# Altium schematic sheet DAC7828_main.SchDoc(DAC7828_main)
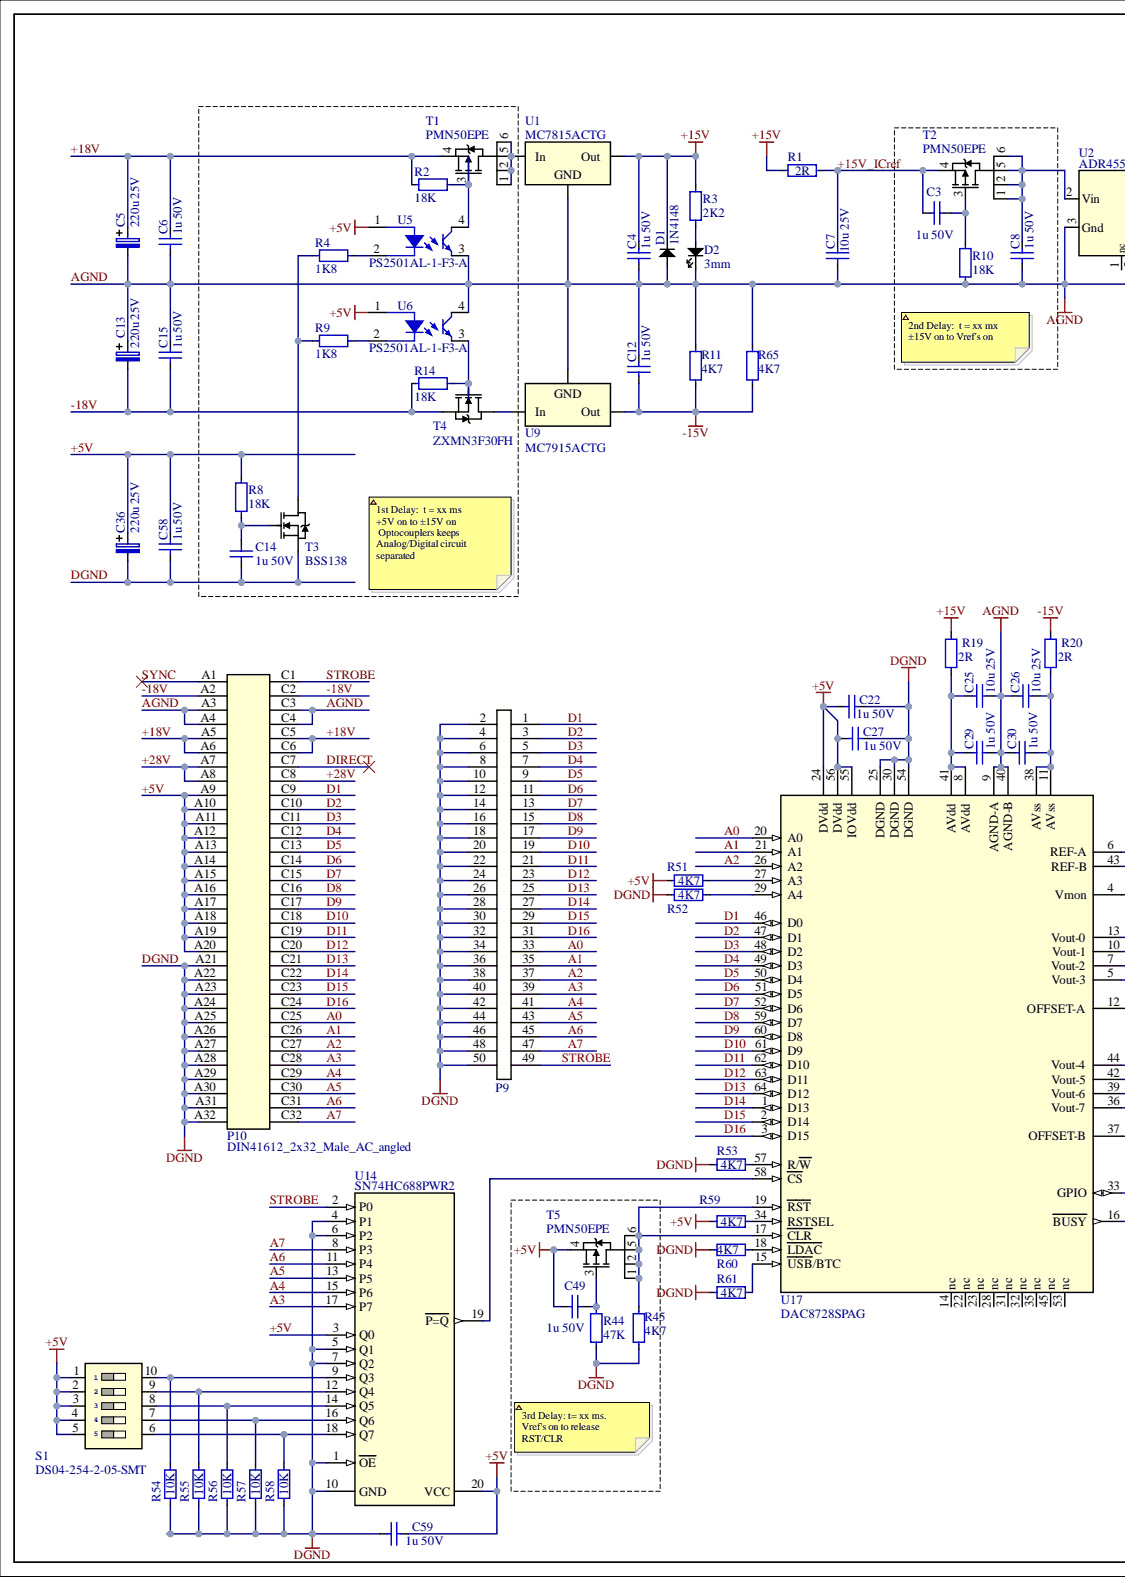
[diagram p0: DAC7828_main.SchDoc, left]
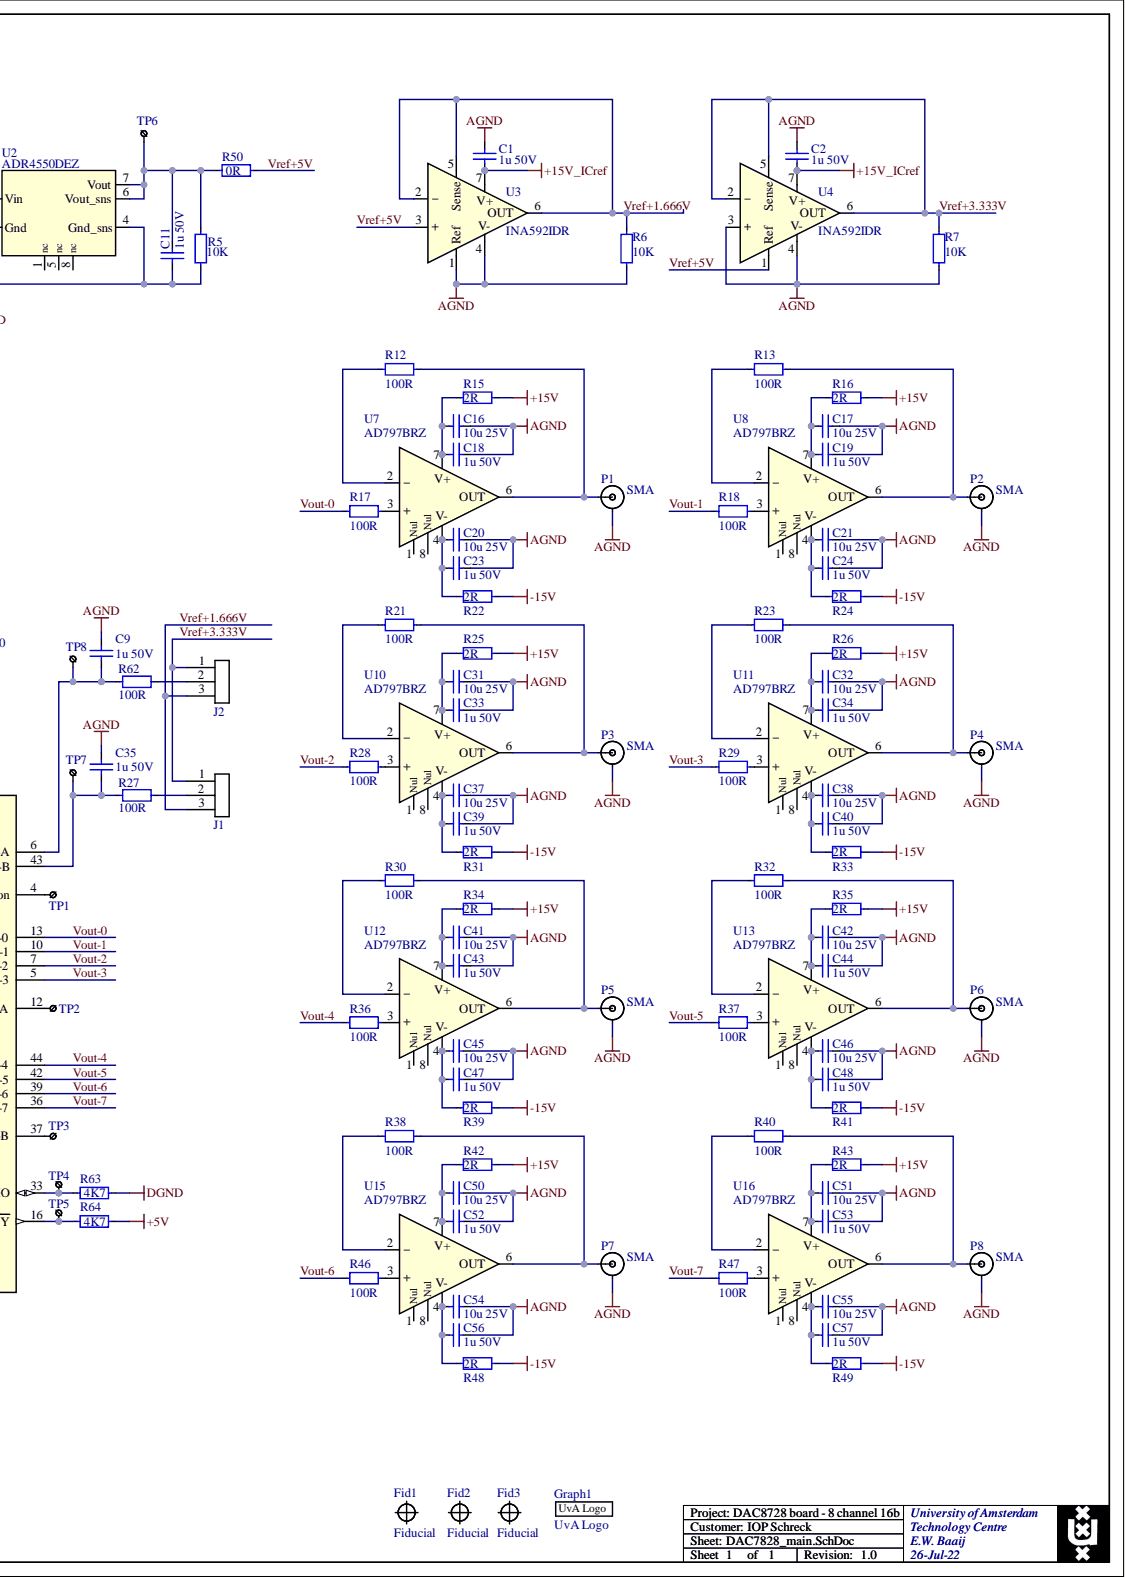
[diagram p1: DAC7828_main.SchDoc, right]

## Parts
C1  1u 50V  Capacitance: 1u  Voltage: 50V  [cap0603MD]
C2  1u 50V  Capacitance: 1u  [cap0603MD]
C3  1u 50V  Capacitance: 1u  Voltage: 50V  [cap0603MD]
C4  1u 50V  Capacitance: 1u  Voltage: 50V  [cap0603MD]
C5  220u 25V  Capacitance: 220u  Voltage: 25V  [capAluSmd_d8H10mm]
C6  1u 50V  Capacitance: 1u  Voltage: 50V  [cap0603MD]
C7  10u 25V  Capacitance: 10u  Voltage: 25V  [cap0805MD]
C8  1u 50V  Capacitance: 1u  Voltage: 50V  [cap0603MD]
C9  1u 50V  Capacitance: 1u  [cap0603MD]
C11  1u 50V  Capacitance: 1u  Voltage: 50V  [cap0603MD]
C12  1u 50V  Capacitance: 1u  Voltage: 50V  [cap0603MD]
C13  220u 25V  Capacitance: 220u  Voltage: 25V  [capAluSmd_d8H10mm]
C14  1u 50V  Capacitance: 1u  Voltage: 50V  [cap0603MD]
C15  1u 50V  Capacitance: 1u  Voltage: 50V  [cap0603MD]
C16  10u 25V  Capacitance: 10u  [cap0805MD]
C17  10u 25V  Capacitance: 10u  [cap0805MD]
C18  1u 50V  Capacitance: 1u  Voltage: 50V  [cap0603MD]
C19  1u 50V  Capacitance: 1u  Voltage: 50V  [cap0603MD]
C20  10u 25V  Capacitance: 10u  [cap0805MD]
C21  10u 25V  Capacitance: 10u  [cap0805MD]
C22  1u 50V  Capacitance: 1u  Voltage: 50V  [cap0603MD]
C23  1u 50V  Capacitance: 1u  Voltage: 50V  [cap0603MD]
C24  1u 50V  Capacitance: 1u  Voltage: 50V  [cap0603MD]
C25  10u 25V  Capacitance: 10u  [cap0805MD]
C26  10u 25V  Capacitance: 10u  [cap0805MD]
C27  1u 50V  Capacitance: 1u  Voltage: 50V  [cap0603MD]
C29  1u 50V  Capacitance: 1u  Voltage: 50V  [cap0603MD]
C30  1u 50V  Capacitance: 1u  Voltage: 50V  [cap0603MD]
C31  10u 25V  Capacitance: 10u  Voltage: 25V  [cap0805MD]
C32  10u 25V  Capacitance: 10u  Voltage: 25V  [cap0805MD]
C33  1u 50V  Capacitance: 1u  Voltage: 50V  [cap0603MD]
C34  1u 50V  Capacitance: 1u  Voltage: 50V  [cap0603MD]
C35  1u 50V  Capacitance: 1u  Voltage: 50V  [cap0603MD]
C36  220u 25V  Capacitance: 220u  Voltage: 25V  [capAluSmd_d8H10mm]
C37  10u 25V  Capacitance: 10u  [cap0805MD]
C38  10u 25V  Capacitance: 10u  [cap0805MD]
C39  1u 50V  Capacitance: 1u  Voltage: 50V  [cap0603MD]
C40  1u 50V  Capacitance: 1u  Voltage: 50V  [cap0603MD]
C41  10u 25V  Capacitance: 10u  [cap0805MD]
C42  10u 25V  Capacitance: 10u  Voltage: 25V  [cap0805MD]
C43  1u 50V  Capacitance: 1u  Voltage: 50V  [cap0603MD]
C44  1u 50V  Capacitance: 1u  Voltage: 50V  [cap0603MD]
C45  10u 25V  Capacitance: 10u  [cap0805MD]
C46  10u 25V  Capacitance: 10u  [cap0805MD]
C47  1u 50V  Capacitance: 1u  Voltage: 50V  [cap0603MD]
C48  1u 50V  Capacitance: 1u  Voltage: 50V  [cap0603MD]
C49  1u 50V  Capacitance: 1u  Voltage: 50V  [cap0603MD]
C50  10u 25V  Capacitance: 10u  Voltage: 25V  [cap0805MD]
C51  10u 25V  Capacitance: 10u  Voltage: 25V  [cap0805MD]
C52  1u 50V  Capacitance: 1u  Voltage: 50V  [cap0603MD]
C53  1u 50V  Capacitance: 1u  Voltage: 50V  [cap0603MD]
C54  10u 25V  Capacitance: 10u  [cap0805MD]
C55  10u 25V  Capacitance: 10u  [cap0805MD]
C56  1u 50V  Capacitance: 1u  Voltage: 50V  [cap0603MD]
C57  1u 50V  Capacitance: 1u  Voltage: 50V  [cap0603MD]
C58  1u 50V  Capacitance: 1u  Voltage: 50V  [cap0603MD]
C59  1u 50V  Capacitance: 1u  Voltage: 50V  [cap0603MD]
D1  1N4148  [SOD2513X100N]
D2  3mm  [LED_3MM_PCB_EDGE_SMD]
Fid1  Fiducial  [fiducial]
Fid2  Fiducial  [fiducial]
Fid3  Fiducial  [fiducial]
Graph1  UvA Logo  Type: Logo  [UvA_Logo]
J1  Header 2.54  [Header254-1x3]  (value not drawn)
J2  Header 2.54  [Header254-1x3]  (value not drawn)
P1  SMA  [619540-1]
P2  SMA  [619540-1]
P3  SMA  [619540-1]
P4  SMA  [619540-1]
P5  SMA  [619540-1]
P6  SMA  [619540-1]
P7  SMA  [619540-1]
P8  SMA  [619540-1]
P9  Header 2.54  [Header254-2x25]  (value not drawn)
P10  DIN41612_2x32_Male_AC_angled  [DIN41612_2x32_Male_AC_angled]
R1  2R  [res0603MD]
R2  18K  [res0603MD]
R3  2K2  [res0603MD]
R4  1K8  [res0603MD]
R5  10K  [res0603MD]
R6  10K  [res0603MD]
R7  10K  [res0603MD]
R8  18K  [res0603MD]
R9  1K8  [res0603MD]
R10  18K  [res0603MD]
R11  4K7  [res0603MD]
R12  100R  [res0603MD]
R13  100R  [res0603MD]
R14  18K  [res0603MD]
R15  2R  [res0603MD]
R16  2R  [res0603MD]
R17  100R  [res0603MD]
R18  100R  [res0603MD]
R19  2R  [res0603MD]
R20  2R  [res0603MD]
R21  100R  [res0603MD]
R22  2R  [res0603MD]
R23  100R  [res0603MD]
R24  2R  [res0603MD]
R25  2R  [res0603MD]
R26  2R  [res0603MD]
R27  100R  [res0603MD]
R28  100R  [res0603MD]
R29  100R  [res0603MD]
R30  100R  [res0603MD]
R31  2R  [res0603MD]
R32  100R  [res0603MD]
R33  2R  [res0603MD]
R34  2R  [res0603MD]
R35  2R  [res0603MD]
R36  100R  [res0603MD]
R37  100R  [res0603MD]
R38  100R  [res0603MD]
R39  2R  [res0603MD]
R40  100R  [res0603MD]
R41  2R  [res0603MD]
R42  2R  [res0603MD]
R43  2R  [res0603MD]
R44  47K  [res0603MD]
R45  4K7  [res0603MD]
R46  100R  [res0603MD]
R47  100R  [res0603MD]
R48  2R  [res0603MD]
R49  2R  [res0603MD]
R50  0R  [res0603MD]
R51  4K7  [res0603MD]
R52  4K7  [res0603MD]
R53  4K7  [res0603MD]
R54  10K  [res0603HD]
R55  10K  [res0603HD]
R56  10K  [res0603HD]
R57  10K  [res0603HD]
R58  10K  [res0603HD]
R59  4K7  [res0603MD]
R60  4K7  [res0603MD]
R61  4K7  [res0603MD]
R62  100R  [res0603MD]
R63  4K7  [res0603MD]
R64  4K7  [res0603MD]
R65  4K7  [res0603MD]
S1  DS04-254-2-05-SMT  [DS04-254-2-05-SMT]
T1  PMN50EPE  [TSOP95P280X110-6N]
T2  PMN50EPE  [TSOP95P280X110-6N]
T3  BSS138  [SOT95P230X110-3N]
T4  ZXMN3F30FH  [SOT95P230X110-3N]
T5  PMN50EPE  [TSOP95P280X110-6N]
TP1  Testpoint  [Testpoint_1mm]  (value not drawn)  (no BOM)
TP2  Testpoint  [Testpoint_1mm]  (value not drawn)  (no BOM)
TP3  Testpoint  [Testpoint_1mm]  (value not drawn)  (no BOM)
TP4  Testpoint  [Testpoint_1mm]  (value not drawn)  (no BOM)
TP5  Testpoint  [Testpoint_1mm]  (value not drawn)  (no BOM)
TP6  Testpoint  [Testpoint_1mm]  (value not drawn)  (no BOM)
TP7  Testpoint  [Testpoint_1mm]  (value not drawn)  (no BOM)
TP8  Testpoint  [Testpoint_1mm]  (value not drawn)  (no BOM)
U1  MC7815ACTG  [TO220-3_FLAT]
U2  ADR4550DEZ  [LCCS127P503X450X221-8M]
U3  INA592IDR  [SOIC127P600X175-8N]
U4  INA592IDR  [SOIC127P600X175-8N]
U5  PS2501AL-1-F3-A  [SOP254P960X380-4N]
U6  PS2501AL-1-F3-A  [SOP254P960X380-4N]
U7  AD797BRZ  [SOIC127P600X175-8N]
U8  AD797BRZ  [SOIC127P600X175-8N]
U9  MC7915ACTG  [TO220-3_FLAT]
U10  AD797BRZ  [SOIC127P600X175-8N]
U11  AD797BRZ  [SOIC127P600X175-8N]
U12  AD797BRZ  [SOIC127P600X175-8N]
U13  AD797BRZ  [SOIC127P600X175-8N]
U14  SN74HC688PWR2  [SOIC127P1030X265-20N]
U15  AD797BRZ  [SOIC127P600X175-8N]
U16  AD797BRZ  [SOIC127P600X175-8N]
U17  DAC8728SPAG  [TSQFP50P1200X1200X105-64N]

## Nets
+15V: C4.1 D1.1 R1.2 R3.2 R15.1 R16.1 R19.2 R25.1 R26.1 R34.1 R35.1 R42.1 R43.1 U1.3
-15V: C12.2 R11.1 R20.2 R22.1 R24.1 R31.1 R33.1 R39.1 R41.1 R48.1 R49.1 R65.1 U9.3
+5V: C22.1 C27.1 C36.P C49.1 C58.1 C59.2 P10.A9 P10.A10 P10.A11 P10.A12 P10.A13 P10.A14 P10.A15 P10.A16 P10.A17 P10.A18 P10.A19 P10.A20 R8.2 R51.1 R59.1 R64.2 S1.1 S1.2 S1.3 S1.4 S1.5 T5.4 U5.1 U6.1 U14.3(Q0) U14.20(VCC) U17.24(DVdd) U17.55(IOVdd) U17.56(DVdd)
+15V_ICref: C1.1 C2.1 C3.1 C7.2 R1.1 T2.4 U3.7(V+) U4.7(V+)
+18V: C5.P C6.1 P10.A5 P10.A6 P10.C5 P10.C6 R2.1 T1.4
+28V: P10.A7 P10.A8 P10.C8
-18V: C13.N C15.2 P10.A2 P10.C2 R14.1 T4.2
A0: P9.33 P10.C25 U17.20(A0)
A1: P9.35 P10.C26 U17.21(A1)
A2: P9.37 P10.C27 U17.26(A2)
A3: P9.39 P10.C28 U14.17(P7)
A4: P9.41 P10.C29 U14.15(P6)
A5: P9.43 P10.C30 U14.13(P5)
A6: P9.45 P10.C31 U14.11(P4)
A7: P9.47 P10.C32 U14.8(P3)
AGND: C1.2 C2.2 C4.2 C5.N C6.2 C7.1 C8.2 C9.2 C11.2 C12.1 C13.P C15.1 C16.2 C17.2 C18.2 C19.2 C20.2 C21.2 C23.2 C24.2 C25.2 C26.1 C29.1 C30.2 C31.2 C32.2 C33.2 C34.2 C35.2 C37.2 C38.2 C39.2 C40.2 C41.2 C42.2 C43.2 C44.2 C45.2 C46.2 C47.2 C48.2 C50.2 C51.2 C52.2 C53.2 C54.2 C55.2 C56.2 C57.2 D1.2 D2.1 P1.2 P2.2 P3.2 P4.2 P5.2 P6.2 P7.2 P8.2 P10.A3 P10.A4 P10.C3 P10.C4 R5.1 R6.1 R7.1 R10.1 R11.2 R65.2 U1.2 U2.3(Gnd) U2.4(Gnd_sns) U3.1(Ref) U3.4(V-) U4.3(+) U4.4(V-) U5.3 U6.4 U9.1 U17.9(AGND-A) U17.40(AGND-B)
D1: P9.1 P10.C9 U17.46(D0)
D2: P9.3 P10.C10 U17.47(D1)
D3: P9.5 P10.C11 U17.48(D2)
D4: P9.7 P10.C12 U17.49(D3)
D5: P9.9 P10.C13 U17.50(D4)
D6: P9.11 P10.C14 U17.51(D5)
D7: P9.13 P10.C15 U17.52(D6)
D8: P9.15 P10.C16 U17.59(D7)
D9: P9.17 P10.C17 U17.60(D8)
D10: P9.19 P10.C18 U17.61(D9)
D11: P9.21 P10.C19 U17.62(D10)
D12: P9.23 P10.C20 U17.63(D11)
D13: P9.25 P10.C21 U17.64(D12)
D14: P9.27 P10.C22 U17.1(D13)
D15: P9.29 P10.C23 U17.2(D14)
D16: P9.31 P10.C24 U17.3(D15)
DGND: C14.2 C22.2 C27.2 C36.N C58.2 C59.1 P9.2 P9.4 P9.6 P9.8 P9.10 P9.12 P9.14 P9.16 P9.18 P9.20 P9.22 P9.24 P9.26 P9.28 P9.30 P9.32 P9.34 P9.36 P9.38 P9.40 P9.42 P9.44 P9.46 P9.48 P9.50 P10.A21 P10.A22 P10.A23 P10.A24 P10.A25 P10.A26 P10.A27 P10.A28 P10.A29 P10.A30 P10.A31 P10.A32 R44.1 R45.1 R52.1 R53.1 R54.1 R55.1 R56.1 R57.1 R58.1 R60.1 R61.1 R63.2 T3.2 U14.1(OE) U14.4(P1) U14.5(Q1) U14.6(P2) U14.7(Q2) U14.10(GND) U17.25(DGND) U17.30(DGND) U17.54(DGND)
DIRECT: P10.C7
STROBE: P9.49 P10.C1 U14.2(P0)
SYNC: P10.A1
Vout-0: R17.1 U17.13(Vout-0)
Vout-1: R18.1 U17.10(Vout-1)
Vout-2: R28.1 U17.7(Vout-2)
Vout-3: R29.1 U17.5(Vout-3)
Vout-4: R36.1 U17.44(Vout-4)
Vout-5: R37.1 U17.42(Vout-5)
Vout-6: R46.1 U17.39(Vout-6)
Vout-7: R47.1 U17.36(Vout-7)
Vref+1.666V: J1.3 J2.3 R6.2 U3.2(-) U3.5(Sense) U3.6(OUT)
Vref+3.333V: J1.1 J2.1 R7.2 U4.2(-) U4.5(Sense) U4.6(OUT)
Vref+5V: R50.2 U3.3(+) U4.1(Ref)
NetC3_2: C3.2 R10.2 T2.3
NetC8_1: C8.1 T2.1 T2.2 T2.5 T2.6 U2.2(Vin)
NetC9_1: C9.1 R62.1 TP8.1 U17.6(REF-A)
NetC11_1: C11.1 R5.2 R50.1 TP6.1 U2.6(Vout_sns) U2.7(Vout)
NetC14_1: C14.1 R8.1 T3.1
NetC16_1: C16.1 C18.1 R15.2 U7.7(V+)
NetC17_1: C17.1 C19.1 R16.2 U8.7(V+)
NetC20_1: C20.1 C23.1 R22.2 U7.4(V-)
NetC21_1: C21.1 C24.1 R24.2 U8.4(V-)
NetC25_1: C25.1 C29.2 R19.1 U17.8(AVdd) U17.41(AVdd)
NetC26_2: C26.2 C30.1 R20.1 U17.11(AVss) U17.38(AVss)
NetC31_1: C31.1 C33.1 R25.2 U10.7(V+)
NetC32_1: C32.1 C34.1 R26.2 U11.7(V+)
NetC35_1: C35.1 R27.1 TP7.1 U17.43(REF-B)
NetC37_1: C37.1 C39.1 R31.2 U10.4(V-)
NetC38_1: C38.1 C40.1 R33.2 U11.4(V-)
NetC41_1: C41.1 C43.1 R34.2 U12.7(V+)
NetC42_1: C42.1 C44.1 R35.2 U13.7(V+)
NetC45_1: C45.1 C47.1 R39.2 U12.4(V-)
NetC46_1: C46.1 C48.1 R41.2 U13.4(V-)
NetC49_2: C49.2 R44.2 T5.3
NetC50_1: C50.1 C52.1 R42.2 U15.7(V+)
NetC51_1: C51.1 C53.1 R43.2 U16.7(V+)
NetC54_1: C54.1 C56.1 R48.2 U15.4(V-)
NetC55_1: C55.1 C57.1 R49.2 U16.4(V-)
NetD2_2: D2.2 R3.1
NetJ1_2: J1.2 R27.2
NetJ2_2: J2.2 R62.2
NetP1_1: P1.1 R12.2 U7.6(OUT)
NetP2_1: P2.1 R13.2 U8.6(OUT)
NetP3_1: P3.1 R21.2 U10.6(OUT)
NetP4_1: P4.1 R23.2 U11.6(OUT)
NetP5_1: P5.1 R30.2 U12.6(OUT)
NetP6_1: P6.1 R32.2 U13.6(OUT)
NetP7_1: P7.1 R38.2 U15.6(OUT)
NetP8_1: P8.1 R40.2 U16.6(OUT)
NetR2_2: R2.2 T1.3 U5.4
NetR4_1: R4.1 R9.1 T3.3
NetR4_2: R4.2 U5.2
NetR9_2: R9.2 U6.2
NetR12_1: R12.1 U7.2(-)
NetR13_1: R13.1 U8.2(-)
NetR14_2: R14.2 T4.1 U6.3
NetR17_2: R17.2 U7.3(+)
NetR18_2: R18.2 U8.3(+)
NetR21_1: R21.1 U10.2(-)
NetR23_1: R23.1 U11.2(-)
NetR28_2: R28.2 U10.3(+)
NetR29_2: R29.2 U11.3(+)
NetR30_1: R30.1 U12.2(-)
NetR32_1: R32.1 U13.2(-)
NetR36_2: R36.2 U12.3(+)
NetR37_2: R37.2 U13.3(+)
NetR38_1: R38.1 U15.2(-)
NetR40_1: R40.1 U16.2(-)
NetR45_2: R45.2 T5.1 T5.2 T5.5 T5.6 U17.17(CLR) U17.19(RST)
NetR46_2: R46.2 U15.3(+)
NetR47_2: R47.2 U16.3(+)
NetR51_2: R51.2 U17.27(A3)
NetR52_2: R52.2 U17.29(A4)
NetR53_2: R53.2 U17.57(R/W)
NetR54_2: R54.2 S1.10 U14.9(Q3)
NetR55_2: R55.2 S1.9 U14.12(Q4)
NetR56_2: R56.2 S1.8 U14.14(Q5)
NetR57_2: R57.2 S1.7 U14.16(Q6)
NetR58_2: R58.2 S1.6 U14.18(Q7)
NetR59_2: R59.2 U17.34(RSTSEL)
NetR60_2: R60.2 U17.18(LDAC)
NetR61_2: R61.2 U17.15(USB/BTC)
NetR63_1: R63.1 TP4.1 U17.33(GPIO)
NetR64_1: R64.1 TP5.1 U17.16(BUSY)
NetT1_1: T1.1 T1.2 T1.5 T1.6 U1.1
NetT4_3: T4.3 U9.2
NetTP1_1: TP1.1 U17.4(Vmon)
NetTP2_1: TP2.1 U17.12(OFFSET-A)
NetTP3_1: TP3.1 U17.37(OFFSET-B)
NetU14_19: U14.19(P=Q) U17.58(CS)

Not connected: U2 1(nc) 5(nc) 8(nc); U7 1(Nul) 8(Nul); U8 1(Nul) 8(Nul); U10 1(Nul) 8(Nul); U11 1(Nul) 8(Nul); U12 1(Nul) 8(Nul); U13 1(Nul) 8(Nul); U15 1(Nul) 8(Nul); U16 1(Nul) 8(Nul); U17 14(nc) 22(nc) 23(nc) 28(nc) 31(nc) 32(nc) 35(nc) 45(nc) 53(nc)

## Library data of the parts
C1-C4 C6 C8 C9 C11 C12 C14 C15 C18 C19 C22-C24 C27 C29 C30 C33-C35 C39 C40 C43 C44 C47-C49 C52 C53 C56-C59 = Cap0603MD_1u_X5R_50V_10%: Database Table Name: capacitor_generic; id: 123; Precision: 10%; Type: X5R; Component Kind: Standard; CreationDate: 23-Mar-20; Library Name: TC_Parts.DbLib; MyComment: 1u 50V; Partname: Cap0603MD_1u_X5R_50V_10%; Supplier 1: Farnell; Supplier Part Number 1: 3013383; Author: Twe; Checked by: Unchecked
  C2 C9: Voltage: 50V
  C18 C23: ClassName: OutBufClass0
  C19 C24: ClassName: OutBufClass1
C5 C13 C36 = EDK227M025A9MAA: Cap Alu SMD d8h11, ±20%; Manufacturer: Kemet; Database Table Name: capacitor_named; id: 37; Component Kind: Standard; CreationDate: 06-Jul-22 14:38:19; Library Name: TC_Parts.DbLib; ManufacturerCode: EDK227M025A9MAA; MyComment: 220u 25V; Partname: EDK227M025A9MAA; Precision: 20%; Supplier 1: Digi-Key; Supplier Part Number 1: 399-18129-1-ND; Author: EB; Checked by: Unchecked
C7 C16 C17 C20 C21 C25 C26 C31 C32 C37 C38 C41 C42 C45 C46 C50 C51 C54 C55 = Cap0805MD_10u_X5R_25V_10%: Database Table Name: capacitor_generic; id: 146; Precision: 10%; Type: 5XR; Component Kind: Standard; CreationDate: 31-Mar-16 14:12:18; Library Name: TC_Parts.DbLib; MyComment: 10u 25V; Partname: Cap0805MD_10u_X5R_25V_10%; Supplier 1: Farnell; Supplier Part Number 1: 1735530; Author: EB; Checked by: Unchecked
  C16 C20: ClassName: OutBufClass0; Voltage: 25V
  C17 C21: ClassName: OutBufClass1; Voltage: 25V
  C25 C26 C37 C38 C41 C45 C46 C54 C55: Voltage: 25V
D1 = 1N4148WS: Small Signal Diode, Single, 100 V, 150 mA, 1 V, 4 ns, 350 mA; Manufacturer: Multicomp; id: 15; Type: General Purpose; Component Kind: Standard; CreationDate: 26-Aug-16 13:43:40; Database Table Name: diode; Library Name: TC_Parts.DbLib; ManufacturerCode: 1N4148WS; MyComment: 1N4148; Partname: 1N4148WS; Supplier 1: Farnell; Supplier Part Number 1: 1466524; Author: Twe; Checked by: PCB
D2 = LED_3mm_PcbEdgeSmd: Generic 3mm LED Footprint PCB Edge SMD Pads; Database Table Name: diode; id: 40; Component Kind: Standard; CreationDate: 26-May-16 08:35:38; Library Name: TC_Parts.DbLib; MyComment: 3mm; Partname: LED_3mm_PcbEdgeSmd; Supplier 1: Farnell; Type: LED; Author: EB; Checked by: Unchecked
Fid1-Fid3 = Fiducial: Database Table Name: miscellaneous; id: 1; Component Kind: Standard; CreationDate: 31-Mar-16 09:08:33; Description: Fiducial; Library Name: TC_Parts.DbLib; MyComment: Fiducial; Partname: Fiducial; Supplier 1: Farnell; Type: Fiducial; Author: Twe; Checked by: PCB
Graph1 = UvA_Logo: University of Amsterdam Logo; Database Table Name: miscellaneous; id: 4; Component Kind: Standard; CreationDate: 31-Mar-16 13:43:00; Library Name: TC_Parts.DbLib; MyComment: UvA Logo; Partname: UvA_Logo; Supplier 1: Farnell; Author: Twe; Checked by: PCB
J1 J2 = Header254-1x3: Header 2.54p 1x3; Database Table Name: connector; id: 33; Component Kind: Standard; CreationDate: 11-Apr-16 15:00:36; Library Name: TC_Parts.DbLib; MyComment: Header 2.54; Partname: Header254-1x3; Supplier 1: Farnell; Supplier Part Number 1: 1022248; Type: Header; Author: Twe; Checked by: PCB
P1-P8 = 619540-1: SMA right angle through hole, 19mm, 50 Ohm; Manufacturer: TE connectivity; Database Table Name: connector; id: 145; Component Kind: Standard; CreationDate: 31-Mar-16 12:11:50; Library Name: TC_Parts.DbLib; ManufacturerCode: 619540-1; MyComment: SMA; Partname: 619540-1; Supplier 1: Farnell; Supplier Part Number 1: 3133848; Type: SMA; Author: EB; Checked by: Unchecked
P9 = Header254-2x25: Header 2.54p 2x25; Manufacturer: Multicomp; ManufacturerCode: 2213S-50G; Database Table Name: connector; id: 146; Component Kind: Standard; CreationDate: 18-Jan-17 13:47:01; Library Name: TC_Parts.DbLib; MyComment: Header 2.54; Partname: Header254-2x25; Supplier 1: Farnell; Supplier Part Number 1: 2847228; Type: Header; Author: EB; Checked by: Unchecked
P10 = DIN41612: Manufacturer: Hartman; Database Table Name: connector; id: 144; Component Kind: Standard; CreationDate: 28-Jun-22 09:22:47; Description: DIN41612_2x32_Male_AC_angled; Library Name: TC_Parts.DbLib; MyComment: DIN41612_2x32_Male_AC_angled; Partname: DIN41612; Supplier 1: Farnell; Type: Connector; Author: EB; Checked by: Unchecked
R1 R15 R16 R19 R20 R22 R24-R26 R31 R33-R35 R39 R41-R43 R48 R49 = Res0603MD_2R_1%: Database Table Name: resistor_generic; id: 536; Precision: 1%; Component Kind: Standard; CreationDate: 30-Mar-16 10:33:13; Library Name: TC_Parts.DbLib; MyComment: 2R; Partname: Res0603MD_2R_1%; Resistance: 2R; Supplier 1: Farnell; Supplier Part Number 1: 2447327; Author: EB; Checked by: Unchecked
  R15 R22: ClassName: OutBufClass0
  R16 R24: ClassName: OutBufClass1
R2 R8 R10 R14 = Res0603MD_18K_1%: Database Table Name: resistor_generic; id: 342; Precision: 1%; Component Kind: Standard; CreationDate: 30-Mar-16 10:33:13; Library Name: TC_Parts.DbLib; MyComment: 18K; Partname: Res0603MD_18K_1%; Resistance: 18K; Supplier 1: Farnell; Supplier Part Number 1: 9330720; Author: Twe; Checked by: Unchecked
R3 = Res0603MD_2K2_1%: Database Table Name: resistor_generic; id: 320; Precision: 1%; Component Kind: Standard; CreationDate: 30-Mar-16 10:33:13; Library Name: TC_Parts.DbLib; MyComment: 2K2; Partname: Res0603MD_2K2_1%; Resistance: 2K2; Supplier 1: Farnell; Supplier Part Number 1: 9330810; Author: Twe; Checked by: Unchecked
R4 R9 = Res0603MD_1K8_1%: Database Table Name: resistor_generic; id: 318; Precision: 1%; Component Kind: Standard; CreationDate: 30-Mar-16 10:33:13; Library Name: TC_Parts.DbLib; MyComment: 1K8; Partname: Res0603MD_1K8_1%; Resistance: 1K8; Supplier 1: Farnell; Supplier Part Number 1: 9330712; Author: Twe; Checked by: Unchecked
R5-R7 = Res0603MD_10K_1%: Database Table Name: resistor_generic; id: 336; Precision: 1%; Component Kind: Standard; CreationDate: 30-Mar-16 10:33:13; Library Name: TC_Parts.DbLib; MyComment: 10K; Partname: Res0603MD_10K_1%; Resistance: 10K; Supplier 1: Farnell; Supplier Part Number 1: 2446547; Author: TvR; Checked by: Unchecked
R11 R45 R51-R53 R59-R61 R63-R65 = Res0603MD_4K7_1%: Database Table Name: resistor_generic; id: 328; Precision: 1%; Component Kind: Standard; CreationDate: 30-Mar-16 10:33:13; Library Name: TC_Parts.DbLib; MyComment: 4K7; Partname: Res0603MD_4K7_1%; Resistance: 4K7; Supplier 1: Farnell; Supplier Part Number 1: 2447385; Author: Twe; Checked by: Unchecked
R12 R13 R17 R18 R21 R23 R27-R30 R32 R36-R38 R40 R46 R47 R62 = Res0603MD_100R_1%: Database Table Name: resistor_generic; id: 288; Precision: 1%; Component Kind: Standard; CreationDate: 30-Mar-16 10:33:13; Library Name: TC_Parts.DbLib; MyComment: 100R; Partname: Res0603MD_100R_1%; Resistance: 100R; Supplier 1: Farnell; Supplier Part Number 1: 1576263; Author: TvR; Checked by: Unchecked
  R12 R17: ClassName: OutBufClass0
  R13 R18: ClassName: OutBufClass1
R44 = Res0603MD_47K_1%: Database Table Name: resistor_generic; id: 352; Precision: 1%; Component Kind: Standard; CreationDate: 30-Mar-16 10:33:13; Library Name: TC_Parts.DbLib; MyComment: 47K; Partname: Res0603MD_47K_1%; Resistance: 47K; Supplier 1: Farnell; Supplier Part Number 1: 2447376; Author: Twe; Checked by: Unchecked
R50 = Res0603MD_0R_1%: Database Table Name: resistor_generic; id: 264; Precision: 1%; Component Kind: Standard; CreationDate: 30-Mar-16 10:33:13; Library Name: TC_Parts.DbLib; MyComment: 0R; Partname: Res0603MD_0R_1%; Resistance: 0R; Supplier 1: Farnell; Supplier Part Number 1: 2447743; Author: Twe; Checked by: Unchecked
R54-R58 = Res0603HD_10K_1%: Database Table Name: resistor_generic; id: 534; Precision: 1%; Component Kind: Standard; CreationDate: 04-Apr-22 10:27:21; Library Name: TC_Parts.DbLib; MyComment: 10K; Partname: Res0603HD_10K_1%; Resistance: 10K; Supplier 1: Farnell; Supplier Part Number 1: 2446547; Author: RoKo; Checked by: Unchecked
S1 = DS04-254-2-05-SMT: DIP Switch, 5 SPST, SMD; Manufacturer: CUI Devices; ManufacturerCode: DS04-254-2-05BK-SMT; Database Table Name: electromechnical; id: 24; Component Kind: Standard; CreationDate: 05-Jul-22 15:40:19; Library Name: TC_Parts.DbLib; MyComment: DS04-254-2-05-SMT; Partname: DS04-254-2-05-SMT; Supplier 1: Digi-Key; Supplier Part Number 1: DS04-254-2-05BK-SMT; Type: Switch; Author: EB; Checked by: Unchecked
T1 T2 T5 = PMN50EPE: P Channel, MOSFET, -30 V, -6A, 0.045 ohm, SOT457; Manufacturer: NEXPERIA; Database Table Name: transistor; id: 27; Type: MOSFET P; Component Kind: Standard; CreationDate: 08-Jul-22 12:04:36; Library Name: TC_Parts.DbLib; ManufacturerCode: PMN50EPE; MyComment: PMN50EPE; Partname: PMN50EPE; Supplier 1: Farnell; Supplier Part Number 1: 2900296; Author: EB; Checked by: Unchecked
T3 = BSS138: N-Channel Logic Level Enhancement, 3R5; Manufacturer: ON Semi; Database Table Name: transistor; id: 20; Type: MOSFET EN; Component Kind: Standard; CreationDate: 20-May-19 16:27:00; Library Name: TC_Parts.DbLib; ManufacturerCode: BSS138; MyComment: BSS138; Partname: BSS138; Supplier 1: Farnell; Supplier Part Number 1: 9845330; Author: TvR; Checked by: Unchecked
T4 = ZXMN3F30FH: MOSFET Transistor, N Channel, 4.6 A, 30 V, 65 mohm, 4.5Vgs; Manufacturer: ZETEX; id: 26; Type: MOSFET N; Component Kind: Standard; CreationDate: 07-Jul-22 12:16:34; Database Table Name: transistor; Library Name: TC_Parts.DbLib; ManufacturerCode: ZXMN3F30FH; MyComment: ZXMN3F30FH; Partname: ZXMN3F30FH; Supplier 1: Farnell; Supplier Part Number 1: 1583667; Author: EB; Checked by: Unchecked
TP1-TP8 = Testpoint_1mm: Testpoint pad 1mm; Database Table Name: miscellaneous; id: 2; CreationDate: 31-Mar-16 13:33:27; Library Name: TC_Parts.DbLib; MyComment: Testpoint; Partname: Testpoint_1mm; Supplier 1: Farnell; Type: Testpoint; Author: Twe; Checked by: PCB
U1 = MC7815ACTG_Flat: Linear Voltage Regulator,Positive, Fixed, 35V In, 15V And 1A Out; Manufacturer: OnSEMI; Database Table Name: integrated_circuit; id: 191; Component Kind: Standard; CreationDate: 06-Jul-22 13:15:49; Library Name: TC_Parts.DbLib; ManufacturerCode: MC7815ACTG; MyComment: MC7815ACTG; Partname: MC7815ACTG_Flat; Supplier 1: Digi-Key; Type: Power; Author: EB; Checked by: Unchecked
U2 = ADR4550DEZ: Ultralow Noise, High Accuracy  Voltage References; Manufacturer: Analog Devices; Database Table Name: integrated_circuit; id: 187; Component Kind: Standard; CreationDate: 04-Jul-22 15:46:48; Library Name: TC_Parts.DbLib; ManufacturerCode: ADR4550DEZ; MyComment: ADR4550DEZ; Partname: ADR4550DEZ; Supplier 1: Digi-Key; Supplier Part Number 1: 505-ADR4550DEZ-ND; Type: Voltage Reference; Author: EB; Checked by: Unchecked
U3 U4 = INA592IDR: INA592 High-Precision, Wide-Bandwidth e-trim™ Difference Amplifier; Manufacturer: Texas Instruments; Database Table Name: integrated_circuit; id: 186; Component Kind: Standard; CreationDate: 04-Jul-22 14:48:50; Library Name: TC_Parts.DbLib; ManufacturerCode: INA592IDR; MyComment: INA592IDR; Partname: INA592IDR; Supplier 1: Digi-Key; Supplier Part Number 1: 296-INA592IDRCT-ND; Type: Opamp; Author: EB; Checked by: Unchecked
U5 U6 = PS2501AL-1-F3-A: Optocoupler 1ch, Vceo=70V, Ic=30mA, CTR=200-400% @ 5mA; Manufacturer: CEL; Database Table Name: transistor; id: 28; Component Kind: Standard; CreationDate: 11-Jul-22 09:21:13; Library Name: TC_Parts.DbLib; ManufacturerCode: PS2501AL-1-F3-A; MyComment: PS2501AL-1-F3-A; Partname: PS2501AL-1-F3-A; Supplier 1: Farnell; Supplier Part Number 1: 3605298; Type: OptoCoupler; Author: EB; Checked by: Unchecked
U7 U8 U10-U13 U15 U16 = AD797BRZ: Ultralow Distortion, Ultralow Noise Op Amp; Manufacturer: Analog Devices; Database Table Name: integrated_circuit; id: 188; Component Kind: Standard; CreationDate: 05-Jul-22 13:39:52; Library Name: TC_Parts.DbLib; ManufacturerCode: AD797BRZ; MyComment: AD797BRZ; Partname: AD797BRZ; Supplier 1: Digi-Key; Supplier Part Number 1: 505-AD797BRZ-ND; Type: OpAmp; Author: EB; Checked by: Unchecked
  U7: ClassName: OutBufClass0
  U8: ClassName: OutBufClass1
U9 = MC7915ACTG_Flat: Linear Voltage Regulator,Negative, Fixed, -35V In, -15V And -1A Out; Manufacturer: OnSEMI; Database Table Name: integrated_circuit; id: 192; Component Kind: Standard; CreationDate: 06-Jul-22 13:25:15; Library Name: TC_Parts.DbLib; ManufacturerCode: MC7915ACTG; MyComment: MC7915ACTG; Partname: MC7915ACTG_Flat; Supplier 1: Digi-Key; Type: Power; Author: EB; Checked by: Unchecked
U14 = SN74HC688PWR2: 8-Bit Identity Comparators; Manufacturer: Texas Instruments; Database Table Name: integrated_circuit; id: 193; Component Kind: Standard; CreationDate: 12-Jul-22 12:33:51; Library Name: TC_Parts.DbLib; ManufacturerCode: SN74HC688PWR2; MyComment: SN74HC688PWR2; Partname: SN74HC688PWR2; Supplier 1: Farnell; Type: Logic; Author: EB; Checked by: Unchecked
U17 = DAC8728SPAG: Octal, 16-Bit, Low-Power, High-Voltage Output, Parallel Input DAC; Manufacturer: Texas Instruments; Database Table Name: integrated_circuit; id: 185; Component Kind: Standard; CreationDate: 29-Jun-22 12:22:22; Library Name: TC_Parts.DbLib; ManufacturerCode: DAC8728SPAG; MyComment: DAC8728SPAG; Partname: DAC8728SPAG; Supplier 1: Digi-Key; Supplier Part Number 1: 296-25472-ND; Type: DAC; Author: EB; Checked by: Unchecked
